# Altium schematic sheet Connectors.SchDoc(Connectors)
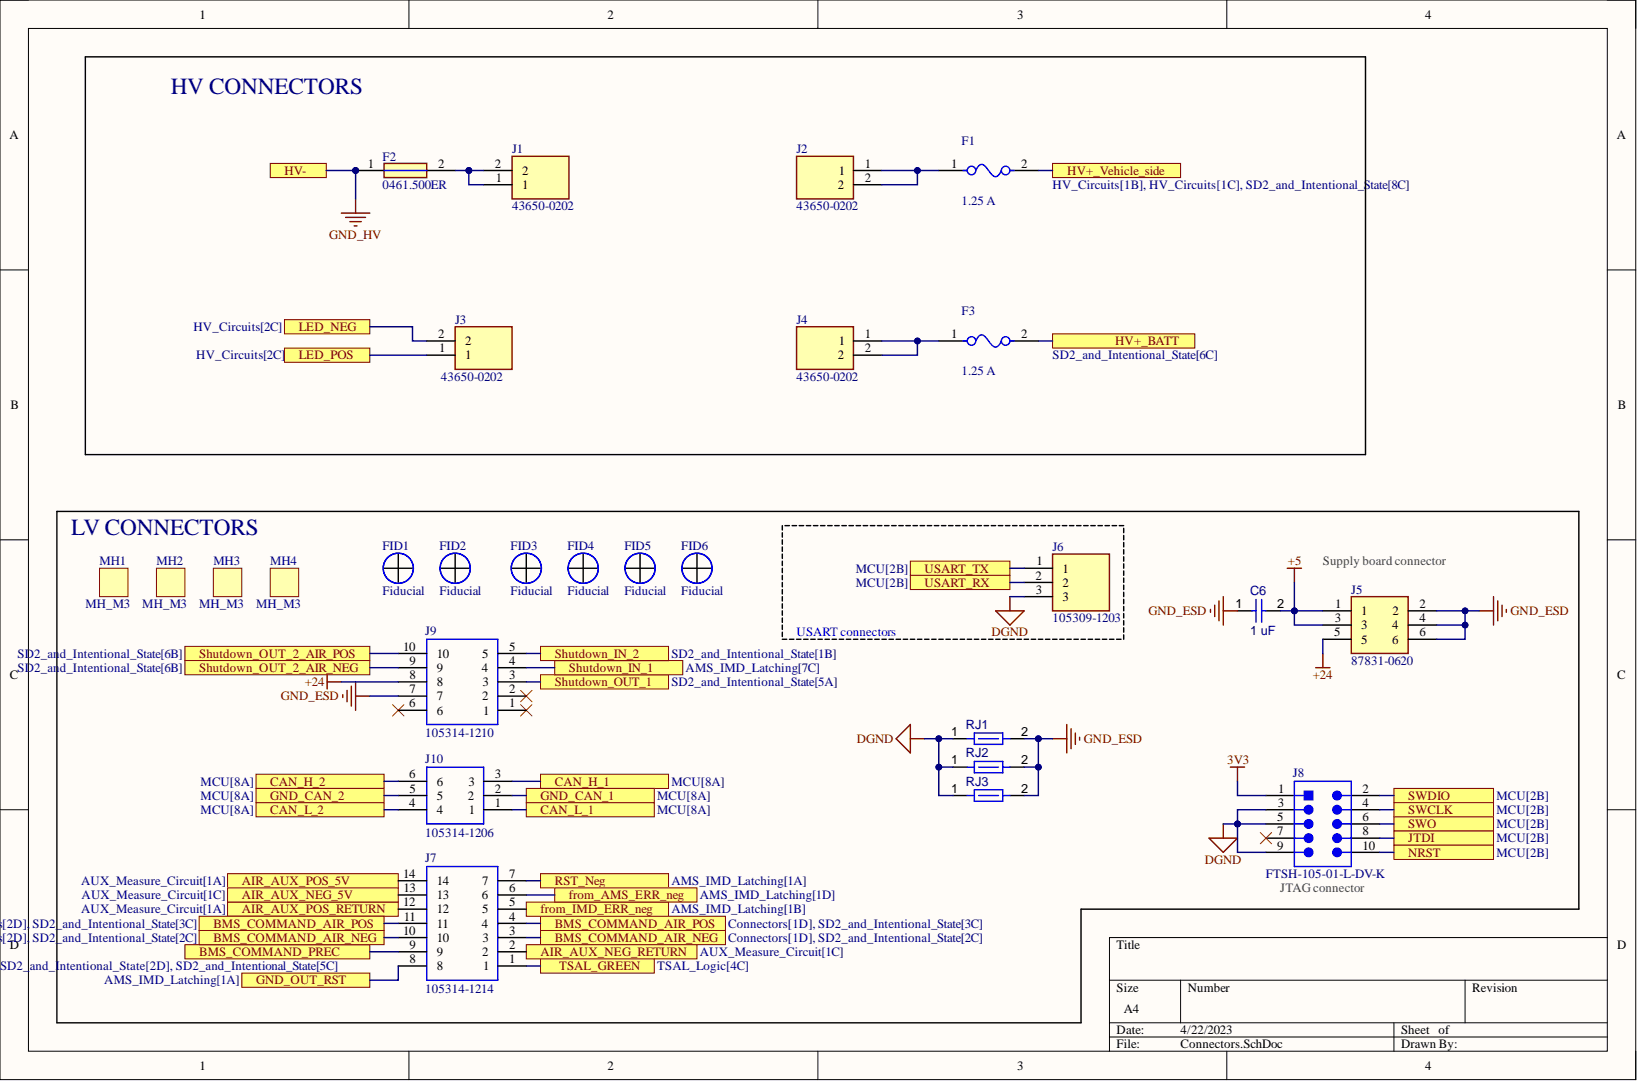
[diagram p0: Connectors.SchDoc]

## Parts
C6  1 uF
F1  1.25 A
F2  0461.500ER
F3  1.25 A
FID1  Fiducial
FID2  Fiducial
FID3  Fiducial
FID4  Fiducial
FID5  Fiducial
FID6  Fiducial
J1  43650-0202
J2  43650-0202
J3  43650-0202
J4  43650-0202
J5  87831-0620
J6  105309-1203
J7  105314-1214
J8  FTSH-105-01-L-DV-K
J9  105314-1210
J10  105314-1206
MH1  MH_M3
MH2  MH_M3
MH3  MH_M3
MH4  MH_M3
RJ1  Net Tie 12x8mil  (value not drawn)
RJ2  Net Tie 12x8mil  (value not drawn)
RJ3  Net Tie 12x8mil  (value not drawn)

## Ports
AIR_AUX_NEG_5V, AIR_AUX_NEG_RETURN, AIR_AUX_POS_5V, AIR_AUX_POS_RETURN, BMS_COMMAND_AIR_NEG, BMS_COMMAND_AIR_POS, BMS_COMMAND_PREC, CAN_H_1, CAN_H_2, CAN_L_1, CAN_L_2, from_AMS_ERR_neg, from_IMD_ERR_neg, GND_CAN_1, GND_CAN_2, GND_OUT_RST, HV+_BATT, HV+_Vehicle_side, HV-, JTDI, LED_NEG, LED_POS, NRST, RST_Neg, Shutdown_IN_1, Shutdown_IN_2, Shutdown_OUT_1, Shutdown_OUT_2_AIR_NEG, Shutdown_OUT_2_AIR_POS, SWCLK, SWDIO, SWO, TSAL_GREEN, USART_RX, USART_TX

## Nets
3V3: J8.1
+5: C6.2 J5.1 J5.3
+24: J5.5 J9.8
DGND: J6.3 J8.3 J8.5 J8.9 RJ1.1 RJ2.1 RJ3.1
GND_ESD: C6.1 J5.2 J5.4 J5.6 J9.7 RJ1.2 RJ2.2 RJ3.2
AIR_AUX_NEG_5V: J7.13 ; port AIR_AUX_NEG_5V
AIR_AUX_NEG_RETURN: J7.2 ; port AIR_AUX_NEG_RETURN
AIR_AUX_POS_5V: J7.14 ; port AIR_AUX_POS_5V
AIR_AUX_POS_RETURN: J7.12 ; port AIR_AUX_POS_RETURN
BMS_COMMAND_AIR_NEG: J7.3 J7.10 ; port BMS_COMMAND_AIR_NEG
BMS_COMMAND_AIR_POS: J7.4 J7.11 ; port BMS_COMMAND_AIR_POS
BMS_COMMAND_PREC: J7.9 ; port BMS_COMMAND_PREC
CAN_H_1: J10.3 ; port CAN_H_1
CAN_H_2: J10.6 ; port CAN_H_2
CAN_L_1: J10.1 ; port CAN_L_1
CAN_L_2: J10.4 ; port CAN_L_2
from_AMS_ERR_neg: J7.6 ; port from_AMS_ERR_neg
from_IMD_ERR_neg: J7.5 ; port from_IMD_ERR_neg
GND_CAN_1: J10.2 ; port GND_CAN_1
GND_CAN_2: J10.5 ; port GND_CAN_2
GND_HV: F2.1 ; port HV-
GND_OUT_RST: J7.8 ; port GND_OUT_RST
HV+_BATT: F3.2 ; port HV+_BATT
HV+_Vehicle_side: F1.2 ; port HV+_Vehicle_side
JTDI: J8.8 ; port JTDI
LED_NEG: J3.2 ; port LED_NEG
LED_POS: J3.1 ; port LED_POS
NRST: J8.10 ; port NRST
RST_Neg: J7.7 ; port RST_Neg
Shutdown_IN_1: J9.4 ; port Shutdown_IN_1
Shutdown_IN_2: J9.5 ; port Shutdown_IN_2
Shutdown_OUT_1: J9.3 ; port Shutdown_OUT_1
Shutdown_OUT_2_AIR_NEG: J9.9 ; port Shutdown_OUT_2_AIR_NEG
Shutdown_OUT_2_AIR_POS: J9.10 ; port Shutdown_OUT_2_AIR_POS
SWCLK: J8.4 ; port SWCLK
SWDIO: J8.2 ; port SWDIO
SWO: J8.6 ; port SWO
TSAL_GREEN: J7.1 ; port TSAL_GREEN
USART_RX: J6.2 ; port USART_RX
USART_TX: J6.1 ; port USART_TX
NetF1_1: F1.1 J2.1 J2.2
NetF2_2: F2.2 J1.1 J1.2
NetF3_1: F3.1 J4.1 J4.2

Not connected: J8 7; J9 1 2 6

## Library data of the parts
C6 = CMP-001-00085-2: VJ0805Y105KXXTW1BC; Tech: SMD; Component Kind: Standard; ComponentLink1Description: Website; ComponentLink1URL: https://www.mouser.it/ProductDetail/Vishay-Vitramon/VJ0805V105MXQPW1BC?qs=wtJBjE46HQsbbuoG%2F6UKXw%3D%3D; Package: 0805; Library Name: EMEA- Squadra Corse PoliTO-  Politecnico di Torino
F1 F3 = CMP-08605-000011-1: 04611.25ER; Manufacturer: Littelfuse Inc; Fuse Blow Speed: Slow; Fuse Current: 1.25A; Fuse I2T: 16.5A2Sec; Fuse Interrupt Ac Current: 60A; Fuse Interrupt Dc Current: 100A; Max Fuse Ac Voltage: 600V; Max Fuse Dc Voltage: 80V; Resistance: 0.104Ω; Tech: SMD; Value: 0.104Ω; Automotive: No; Category: Fuse; Component Kind: Standard; ComponentLink2Description: Datasheet; ComponentLink2URL: https://www.littelfuse.com/~/media/electronics/datasheets/fuses/littelfuse_fuse_461_datasheet.pdf.pdf; ComponentLink3Description: Website; ComponentLink3URL: https://www.mouser.it/ProductDetail/Littelfuse/04611.25ER?qs=KOeOmFnJLTp9%252BkVWBbV9%252Bw%3D%3D; Device Class L1: Circuit Protection; Device Class L2: Fuses; Device Class L3: unset; Digikey Description: FUSE BRD MNT 1.25A 600VAC/80VDC; Height: 3.12mm; Ipc Land Pattern Name: CAPC1010312X312; Lead Free: Yes; Library Name: Altium Content Vault; Manufacturer Part Number: 04611.25ER; Mouser Description: Surface Mount Fuses 600V 1.25A Telecom Fuse; Octopart: https://octopart.com/04611.25er-littelfuse-566039; Package: 0461; RoHS: TRUE; Temperature: -55°C to +125°C; Temperature Range High: +125°C; Temperature Range Low: -55°C; Voltage Rating: 600V
F2 = 0461.500ER: Fuse; Manufacturer_Name: LITTELFUSE; Arrow Part Number: 0461.500ER; Arrow Price/Stock: https://www.arrow.com/en/products/0461.500er/littelfuse?region=nac; Component Kind: Standard; Datasheet Link: https://www.mouser.com/datasheet/2/240/Littelfuse_Fuse_461_Datasheet.pdf-968630.pdf; Height: 3.12mm; Manufacturer_Part_Number: 0461.500ER; Mouser Part Number: 576-0461.500ER; Mouser Price/Stock: https://www.mouser.co.uk/ProductDetail/Littelfuse/0461500ER?qs=vco6xy%252BeH1osMhpXurJZ9g%3D%3D
FID1-FID6 = CMP-00028-00007-10: Component Kind: Standard; Library Name: EMEA- Squadra Corse PoliTO-  Politecnico di Torino
J1-J4 = CMP-040-000019-2: Micro-Fit 3.0 Right-Angle Header; Current: 8.5 A; Tech: THT; Component Kind: Standard; Library Name: EMEA- Squadra Corse PoliTO-  Politecnico di Torino
J5 = CMP-040-000017-1: Header 2.00mm Milli-Grid; Manufacturer_Name: Molex; Component Kind: Standard; Datasheet Link: https://datasheet.datasheetarchive.com/originals/distributors/Datasheets_SAMA/57e229459297fc07c7b624b2610b71d7.pdf; Design Item ID: 87831-0620; Height: 6.4mm; Manufacturer_Part_Number: 87831-0620; Mouser Part Number: 538-87831-0620; Mouser Price/Stock: https://www.mouser.co.uk/ProductDetail/Molex/87831-0620?qs=hzq9O0YpnZvsWagKxngeFQ%3D%3D; Source: SamacSys.SchLib; Library Name: EMEA- Squadra Corse PoliTO-  Politecnico di Torino
J6 = CMP-032-000012-2: Molex Nano-Fit 105309; Current: 8 A; Tech: THT; Component Kind: Standard; Library Name: EMEA- Squadra Corse PoliTO-  Politecnico di Torino
J7 = CMP-002-000025-1: Headers & Wire Housings NanoFit RA Hdr TH DR 14Ckt 0.38um Au Blk; Manufacturer_Name: Molex; Geometry.Height: 9.34mm; Tech: SMD; Component Kind: Standard; ComponentLink1Description: Website; ComponentLink1URL: https://www.mouser.it/ProductDetail/Molex/105314-1214?qs=PqFvFmEiOr6wLIXpvlvqbA%3D%3D; Design Item ID: 105314-1214; Manufacturer_Part_Number: 105314-1214; Mouser Part Number: 538-105314-1214; Mouser Price/Stock: https://www.mouser.co.uk/ProductDetail/Molex/105314-1214?qs=PqFvFmEiOr6wLIXpvlvqbA%3D%3D; Source: 105314-1214.SchLib; Library Name: EMEA- Squadra Corse PoliTO-  Politecnico di Torino
J8 = CMP-2000-05353-1: Male Header, Pitch 1.27 mm, 2 x 5 Position, Height 6.25 mm; Manufacturer: Samtec; Connector Type: Header,Unshrouded; Mating Products: SFMC-105-L2-S-D-TR-ND  -CONN.05"SURFACE10POSSMT  FLE-105-01-G-DV-TR-ND  -CONNSKT1.27MM10POSDUALSMD  FLE-105-01-G-DV-A-P-ND  -CONNSKT1.27MM10POSDUALSMD  FLE-105-01-G-DV-A-ND  -CONNSKT1.27MM10POSDUALSMD  CLP-105-02-G-D-P-TR-ND  -CONNRCPT10POSDUAL.05"SMD  SAM1155-05-ND  -CONNRCPT10POSDUAL.05"SMD  SAM1154-05-ND  -CONNRCPT10POSDUAL.05"SMD  SAM1153-05-ND  -CONNRCPT10POSDUAL.05"SMD  SAM1226-05-ND  -CONNRCPT.05"10POSDUALSMD; Number of Positions: 10; Number of Positions Loaded: All; Other Names: Q3937619; Pitch: 0.050"(1.27mm); Product Training Modules: Board-to-BoardConnectors; Category: Connectors,Interconnects; Color: Black; Component Kind: Standard; Contact Finish: Gold; Contact Finish Thickness: 10µin(0.25µm); Contact Mating Length: 0.120"(3.05mm); Contact Type: MalePin; Datasheet URL: http://suddendocs.samtec.com/prints/ftsh-1xx-xx-xxx-dv-xxx-mkt.pdf; Datasheet Version: Rev. ET, 10/1995; Family: RectangularConnectors-Headers,MalePins; Library Name: Altium Content Vault; Manufacturer URL: http://www.samtec.com/; Mounting Type: SurfaceMount; Number of Rows: 2; Package Description: Male Header, Pitch 1.27 mm, 2 x 5 Position, Height 5.97 mm, Tail Length 4.32 mm; Package Reference: FTSH-105-01-L-DV-K; Package URL: http://suddendocs.samtec.com/prints/ftsh-1xx-xx-xxx-dv-xxx-footprint.pdf; Packaging: Tube; Row Spacing: 0.050"(1.27mm); Series: FTSH; Standard Package: 1; Termination: Solder
J9 = CMP-002-000041-1: Conn Wire to Board HDR 10 POS 2.5mm Solder RA Thru-Hole Nano-Fit Tray; Manufacturer_Name: Molex; Geometry.Height: 9.14mm; Component Kind: Standard; Datasheet Link: https://componentsearchengine.com/Datasheets/1/105314-1210.pdf; Design Item ID: 105314-1210; Manufacturer_Part_Number: 105314-1210; Mouser Part Number: 538-105314-1210; Mouser Price/Stock: https://www.mouser.co.uk/ProductDetail/Molex/105314-1210?qs=PqFvFmEiOr6d4kbm3TMNvg%3D%3D; Source: 105314-1210.SchLib; Library Name: EMEA- Squadra Corse PoliTO-  Politecnico di Torino
J10 = CMP-002-000038-1: Molex Electronics, Series Number 105314, 2.5mm Pitch 6 Way 2 Row Right Angle PCB Header, Solder Termination, 6.5A; Geometry.Height: 9.14mm; Component Kind: Standard; Datasheet Link: http://www.molex.com/webdocs/datasheets/pdf/en-us//1053141206_PCB_HEADERS.pdf; Design Item ID: 105314-1206; Manufacturer_Name: Molex; Manufacturer_Part_Number: 105314-1206; Mouser Part Number: 538-105314-1206; Mouser Price/Stock: https://www.mouser.co.uk/ProductDetail/Molex/105314-1206?qs=PqFvFmEiOr4lOj3SLCQbvg%3D%3D; Source: 105314-1206.SchLib; Library Name: EMEA- Squadra Corse PoliTO-  Politecnico di Torino
MH1-MH4 = CMP-00028-00008-3: Mountinh Hole M3, 3,5 mm hole, 6,5 mm external; Component Kind: Standard; Library Name: EMEA- Squadra Corse PoliTO-  Politecnico di Torino
RJ1-RJ3 = CMP-009-00121-6: Component Kind: Net Tie; Description: Net Tie 12x8mil; Max Operating Temperature: 155°C; Min Operating Temperature: -55°C; Pins: 2; Library Name: EMEA- Squadra Corse PoliTO-  Politecnico di Torino
